# Altium schematic sheet 1_123456_01.SchDoc
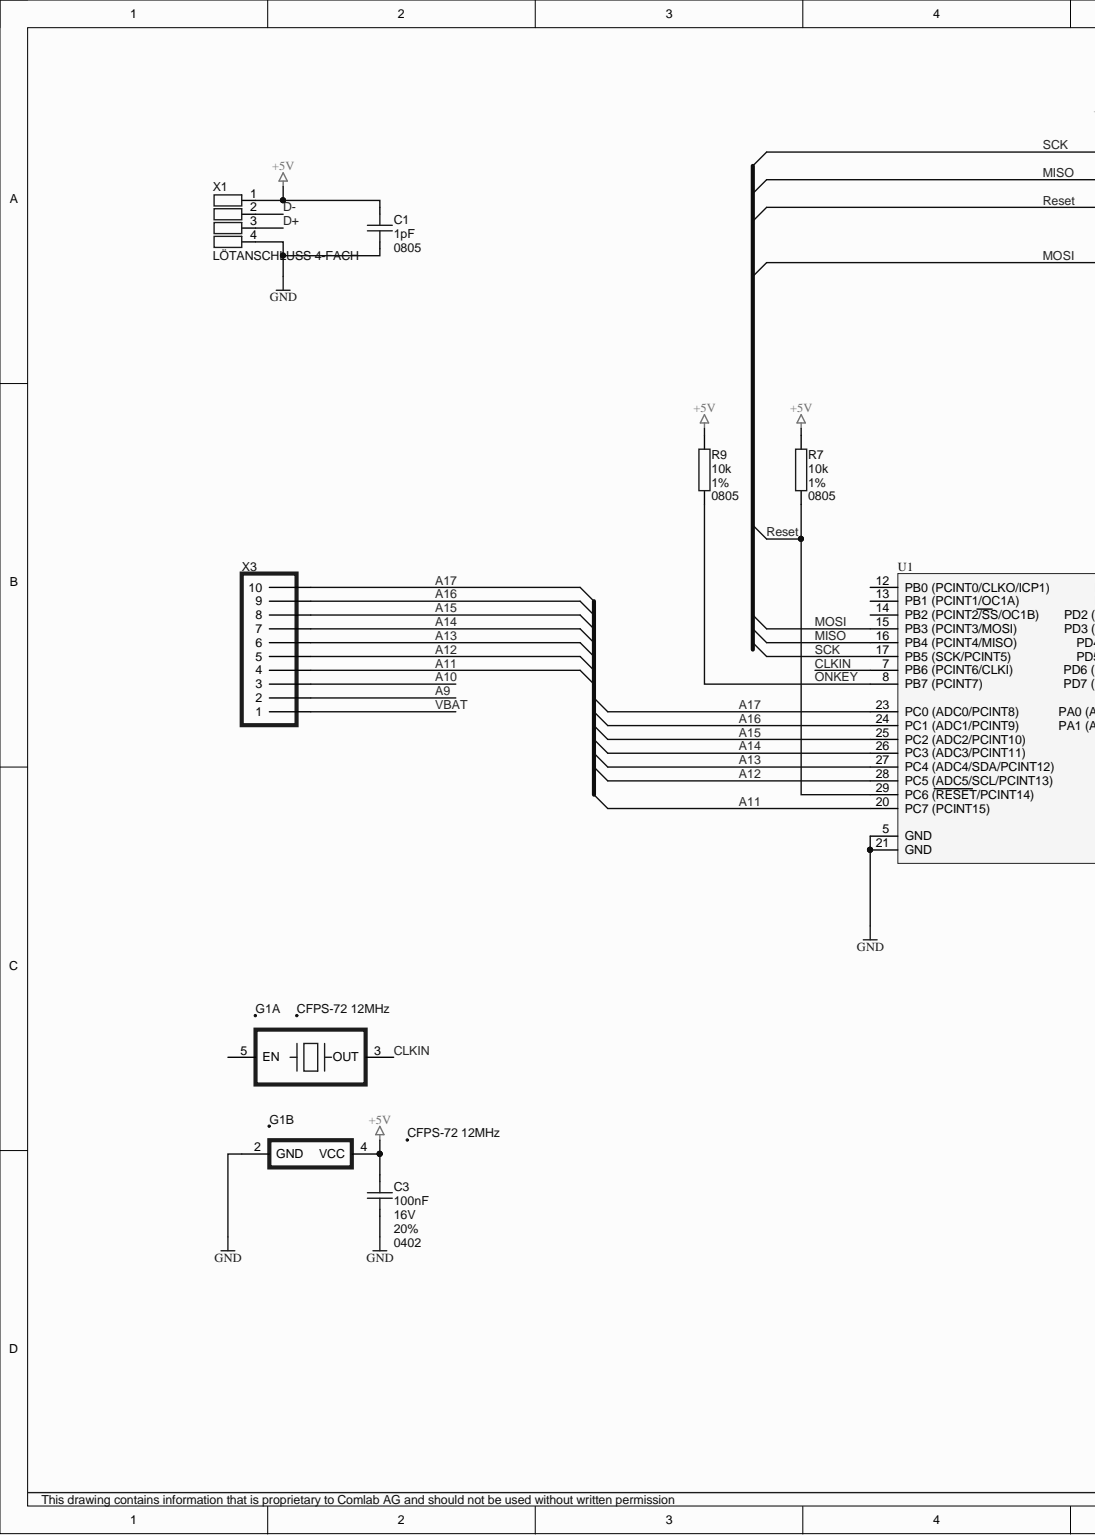
[diagram p0: 1_123456_01.SchDoc, left]
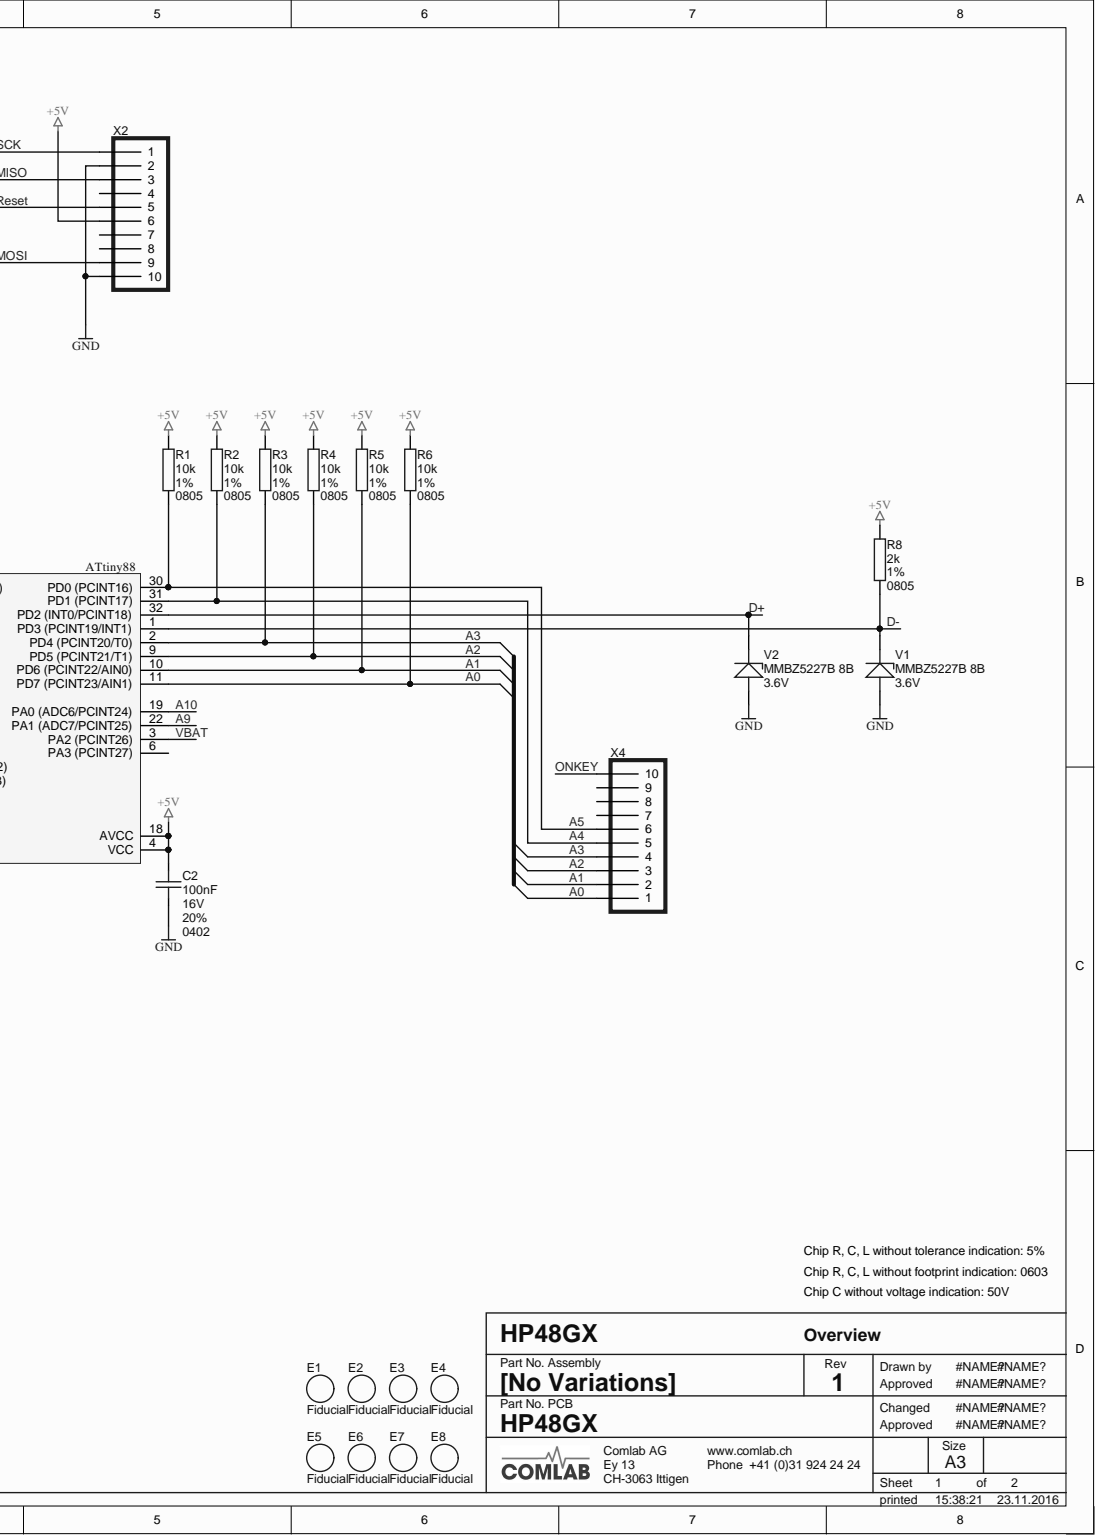
[diagram p1: 1_123456_01.SchDoc, right]

## Parts
C1  1pF  [0805]
C2  100nF  Spannung: 16V  Toleranz: 20%  [0402]
C3  100nF  Spannung: 16V  Toleranz: 20%  [0402]
E1  Fiducial  [ZENTRIER Fiducial]
E2  Fiducial  [ZENTRIER Fiducial]
E3  Fiducial  [ZENTRIER Fiducial]
E4  Fiducial  [ZENTRIER Fiducial]
E5  Fiducial  [ZENTRIER Fiducial]
E6  Fiducial  [ZENTRIER Fiducial]
E7  Fiducial  [ZENTRIER Fiducial]
E8  Fiducial  [ZENTRIER Fiducial]
G1  CFPS-72 12MHz  [TW0203A]  (parts A,B)
R1  10k  Toleranz: 1%  [0805]
R2  10k  Toleranz: 1%  [0805]
R3  10k  Toleranz: 1%  [0805]
R4  10k  Toleranz: 1%  [0805]
R5  10k  Toleranz: 1%  [0805]
R6  10k  Toleranz: 1%  [0805]
R7  10k  Toleranz: 1%  [0805]
R8  2k  Toleranz: 1%  [0805]
R9  10k  Toleranz: 1%  [0805]
U1  ATtiny88  [32A_N]
V1  MMBZ5227B 8B  Zener-Spannung: 3.6V  [SOT-23]
V2  MMBZ5227B 8B  Zener-Spannung: 3.6V  [SOT-23]
X1  LÖTANSCHLUSS 4-FACH  [LÖTANSCHLUSS 4X 2X5MM]  (no BOM)
X2  Header 10P  [XHEAD2R20PR0.5SB]  (value not drawn)
X3  Header 10P  [XHEAD2R20PR0.5SB]  (value not drawn)
X4  Header 10P  [XHEAD2R20PR0.5SB]  (value not drawn)

## Nets
+5V: C1.1 C2.1 C3.1 G1.4(VCC) R1.2 R2.2 R3.2 R4.2 R5.2 R6.2 R7.2 R8.2 R9.2 U1.4(VCC) U1.18(AVCC) X1.1 X2.6
GND: C1.2 C2.2 C3.2 G1.2(GND) U1.5(GND) U1.21(GND) V1.1 V2.1 X1.4 X2.2 X2.10
A0: R6.1 U1.11(PD7_(PCINT23/AIN1)) X4.1
A1: R5.1 U1.10(PD6_(PCINT22/AIN0)) X4.2
A2: R4.1 U1.9(PD5_(PCINT21/T1)) X4.3
A3: R3.1 U1.2(PD4_(PCINT20/T0)) X4.4
A4: R2.1 U1.31(PD1_(PCINT17)) X4.5
A5: R1.1 U1.30(PD0_(PCINT16)) X4.6
A9: U1.22(PA1_(ADC7/PCINT25)) X3.2
A10: U1.19(PA0_(ADC6/PCINT24)) X3.3
A11: U1.20(PC7_(PCINT15)) X3.4
A12: U1.28(PC5_(ADC5/SCL/PCINT13)) X3.5
A13: U1.27(PC4_(ADC4/SDA/PCINT12)) X3.6
A14: U1.26(PC3_(ADC3/PCINT11)) X3.7
A15: U1.25(PC2_(ADC2/PCINT10)) X3.8
A16: U1.24(PC1_(ADC1/PCINT9)) X3.9
A17: U1.23(PC0_(ADC0/PCINT8)) X3.10
CLKIN: G1.3(OUT) U1.7(PB6_(PCINT6/CLKI))
D+: U1.32(PD2_(INT0/PCINT18)) V2.3 X1.3
D-: R8.1 U1.1(PD3_(PCINT19/INT1)) V1.3 X1.2
MISO: U1.16(PB4_(PCINT4/MISO)) X2.3
MOSI: U1.15(PB3_(PCINT3/MOSI)) X2.9
ONKEY: R9.1 U1.8(PB7_(PCINT7)) X4.10
RESET: R7.1 U1.29(PC6_(RESET/PCINT14)) X2.5
SCK: U1.17(PB5_(SCK/PCINT5)) X2.1
VBAT: U1.3(PA2_(PCINT26)) X3.1

Not connected: G1 5(EN); U1 6(PA3_(PCINT27)) 12(PB0_(PCINT0/CLKO/ICP1)) 13(PB1_(PCINT1/OC1A)) 14(PB2_(PCINT2/SS/OC1B)); X2 4 7 8; X4 7 8 9

## Library data of the parts
C1 = (1pF  0805  15.00064: Kond/Chip-Kondensator; Artikelnummer IN-ERP: 101384; Bezeichnung 1: 1pF/50V, ±0.1pF, COG, 0805; Grundpreis: 0.0083; Lagerbestand: 2914; Lieferant: Rutronik; Lieferant Best.Nr: KKK18586; Material Gruppe: 07; Status Artikel: Normal; Toleranz: 0.1p; Artikelnummer Damgaard: 15.00064; Bezeichnung 2: Chip-Kondensator; Component Kind: Standard; Datum Library Update: 26.08.2016; Rev: 1; Value: 1pF; Library Name: Library Comlab.IntLib
C2 C3 = 1uF  0402  118194: Kond/Chip-Kondensator; Grundpreis: 0.0258; Lagerbestand: 876; Lieferant: DIGI-KEY; Lieferant Best.Nr: PCC2257CT-ND; Material Gruppe: 07; Status Artikel: Normal; Bezeichnung 2: Chip-Kondensator; Component Kind: Standard; Datum Library Update: 26.08.2016; Library Name: HP48GX_01_1.SCHLIB; Rev: 1; Value: 100nF
E1-E8 = ZENTRIERMARKE Fiducial: Zubehör/Zentriermarke, 2...8x diagonal auf jedem Print; Artikelnummer IN-ERP: Zentriermarke Fiducial; Component Kind: Standard; Rev: 1; Value: Fiducial; Library Name: Library Comlab.IntLib
G1 = OSZI: 8MHz TW0203  TW0203A  117861: RF/OSZI; Artikelnummer IN-ERP: 117861; Bezeichnung 1: TW0203A, SMD 3.2x5mm; Bezeichnung 2: Crystal-Oscill. 8MHz, ±30ppm@-20...+70°C, 3.3V; Lagerbestand: 45; Material Gruppe: 09; Status Artikel: Normal; Component Kind: Standard; ComponentLink1Description: Datenblatt; Datum Library Update: 07.04.2016; Library Name: HP48GX_01.SCHLIB; Rev: 1; Value: CFPS-72 12MHz; ComponentLink1URL: g:\Entwicklung\DATENBLAETTER\Artikelnummer COMLAB\117861\
R1-R9 = (1K  0805  14.00130: Wid/Chip-Widerstand; Artikelnummer IN-ERP: 101095; Bezeichnung 1: 1K, 1%, 0.1W, 0805; Grundpreis: 0.0057; Lagerbestand: 5992; Lieferant: Rutronik; Lieferant Best.Nr: WRC32151; Material Gruppe: 06; Status Artikel: Normal; Artikelnummer Damgaard: 14.00130; Bezeichnung 2: Chip-Widerstand; Component Kind: Standard; Datum Library Update: 26.08.2016; Rev: 1; Library Name: Library Comlab.IntLib
  R1-R7 R9: Value: 10k
  R8: Value: 2k
U1 = ATtiny88-AU: 8-bit AVR Microcontroller, 1.8-5.5V, 8KB Flash, 64 Bytes EEPROM, 512 Bytes SRAM, 32-pin TQFP, Industrial Grade (-40°C to 85°C), Pb-Free; Code_JEDEC: MS-026-ABA; Component Kind: Standard; ComponentLink1Description: Manufacturer Link; ComponentLink1URL: http://www.atmel.com/products/avr/default.asp?; ComponentLink2Description: ATtiny48/88 Datasheet; ComponentLink2URL: http://www.atmel.com/dyn/resources/prod_documents/doc8008.pdf; ComponentLink3Description: ATtiny48/88 Summary; ComponentLink3URL: http://www.atmel.com/dyn/resources/prod_documents/8008S.pdf; ComponentLink4Description: Atmel.com Home; ComponentLink4URL: http://www.atmel.com; DatasheetVersion: revC, Mar-2009; Library Name: HP48GX_01.SCHLIB; PackageDescription: 32-Lead, 7x7x1.0 mm Body, 0.8mm Lead Pitch, Thin Profile Plastic Quad Flat Package (TQFP); PackageReference: 32A; PackageVersion: revB, Oct-2001; Published: 1-Apr-2010; Supplier 1: Digi-Key; Supplier 2: Farnell; Supplier 3: Mouser; Supplier Part Number 1: ATTINY88-AU-ND; Supplier Part Number 2: 1704570; Supplier Part Number 3: 556-ATTINY88-AU; Publisher: Altium Limited
V1 V2 = MMBZ5227B 8B  SOT-23  10.00022: Semidisc/Zener-Diode; Artikelnummer IN-ERP: 100583; Bezeichnung 1: MMBZ5227B 8B, SOT-23; Bezeichnung 2: Zener-Diode 3.6V, 250mW; Grundpreis: 0.0355; Lagerbestand: 768; Lieferant: DIGI-KEY; Lieferant Best.Nr: 568-6327-6-ND; Material Gruppe: 04; Status Artikel: Normal; Artikelnummer Damgaard: 10.00022; Component Kind: Standard; ComponentLink1Description: Datenblatt; Datum Library Update: 26.08.2016; Rev: 1; Value: MMBZ5227B 8B; ComponentLink1URL: G:\Entwicklung\DATENBLAETTER\Artikelnummer COMLAB\100583; Library Name: Library Comlab.IntLib
X1 = LÖTANSCHLUSS 4-FACH  LÖTANSCHLUSS 4X 2X5MM  -: Stecker/Lötanschluss; Artikelnummer Damgaard: -; Beschrieb: 4x 2x5mm; Rev: 1; Value: LÖTANSCHLUSS 4-FACH; Library Name: Library Comlab.IntLib
X2-X4 = HEADER10P  XHEAD2R20PR0.5SB  119896: Stecker/Headerf Stehend; Artikelnummer IN-ERP: 119896; Bezeichnung 1: 2x 5Pm; Bezeichnung 2: R1.27; Grundpreis: 2.0149; Herst. 1: Harwin; Herst. 1 ArtNr.: M50-3001045; Lagerbestand: 5; Lieferant: DIGI-KEY; Lieferant Best.Nr: 952-1368-5-ND; Material Gruppe: 35; Status Artikel: Normal; Component Kind: Standard; ComponentLink1Description: Datenblatt; Datum Library Update: 26.08.2016; Library Name: HP48GX_01_2.SCHLIB; Rev: 1
